# Altium schematic sheet RFD900x Adapter - 2.SchDoc("RFD900x Adapter - 2")
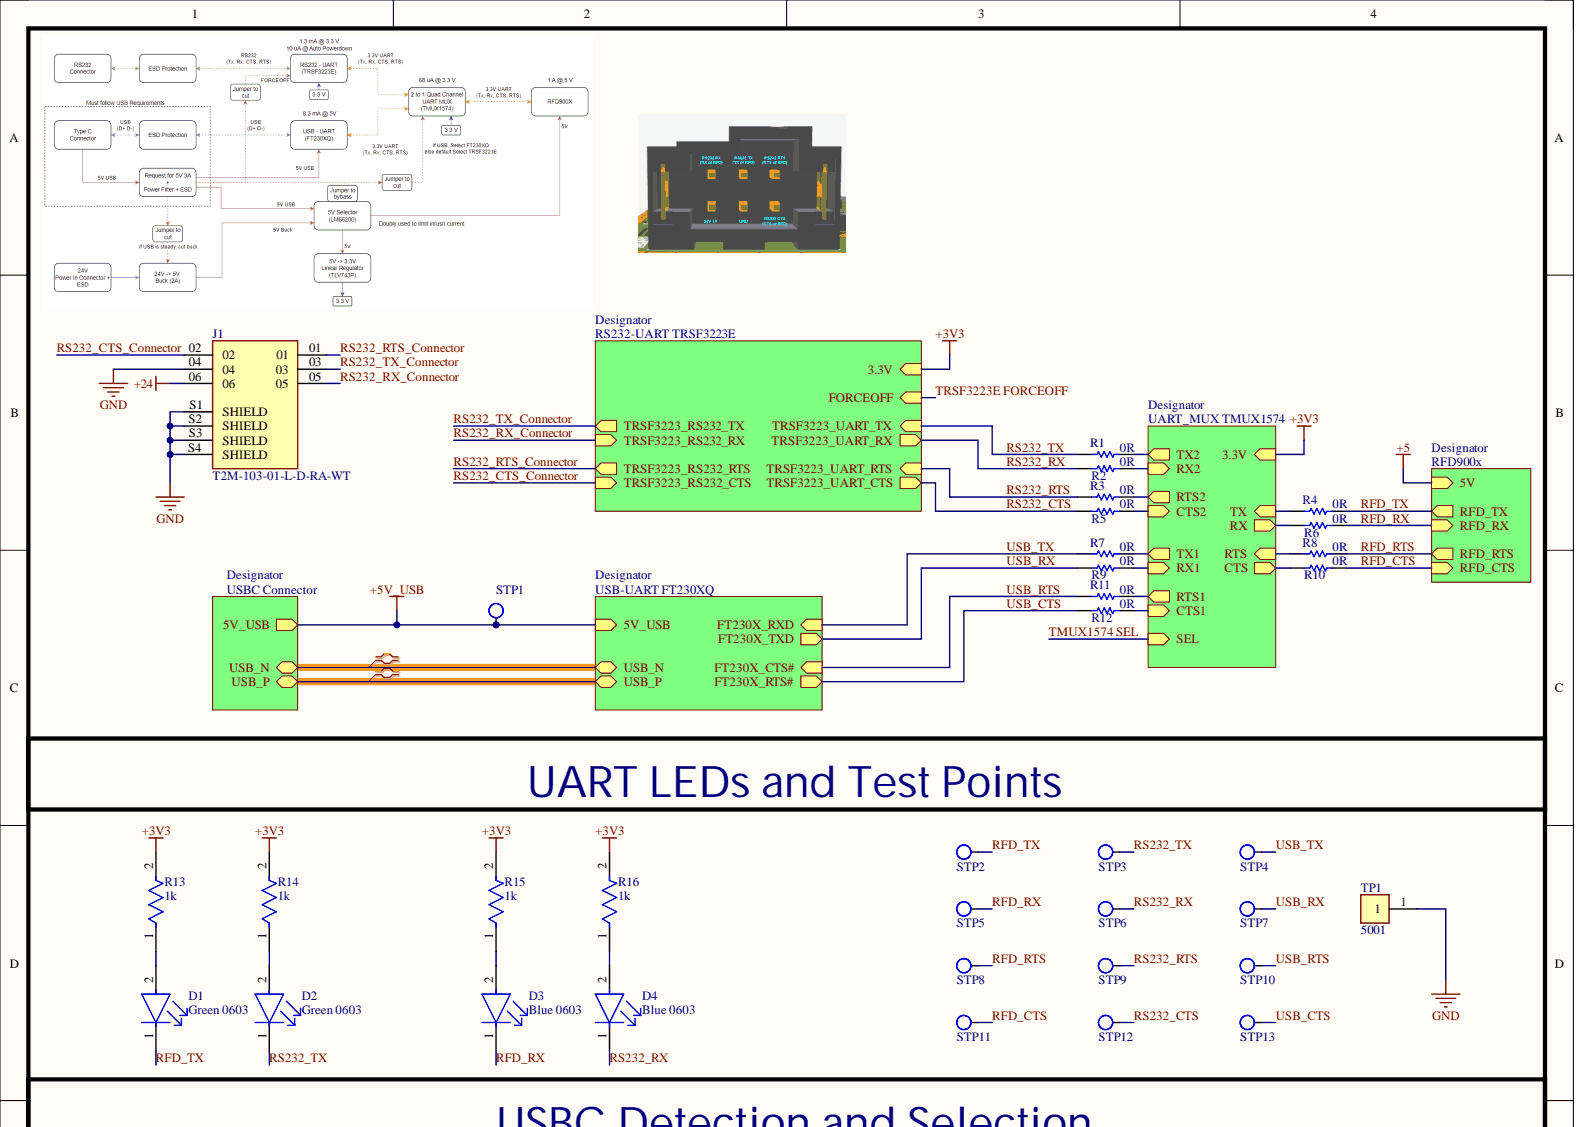
[diagram p0: RFD900x Adapter - 2.SchDoc, top]
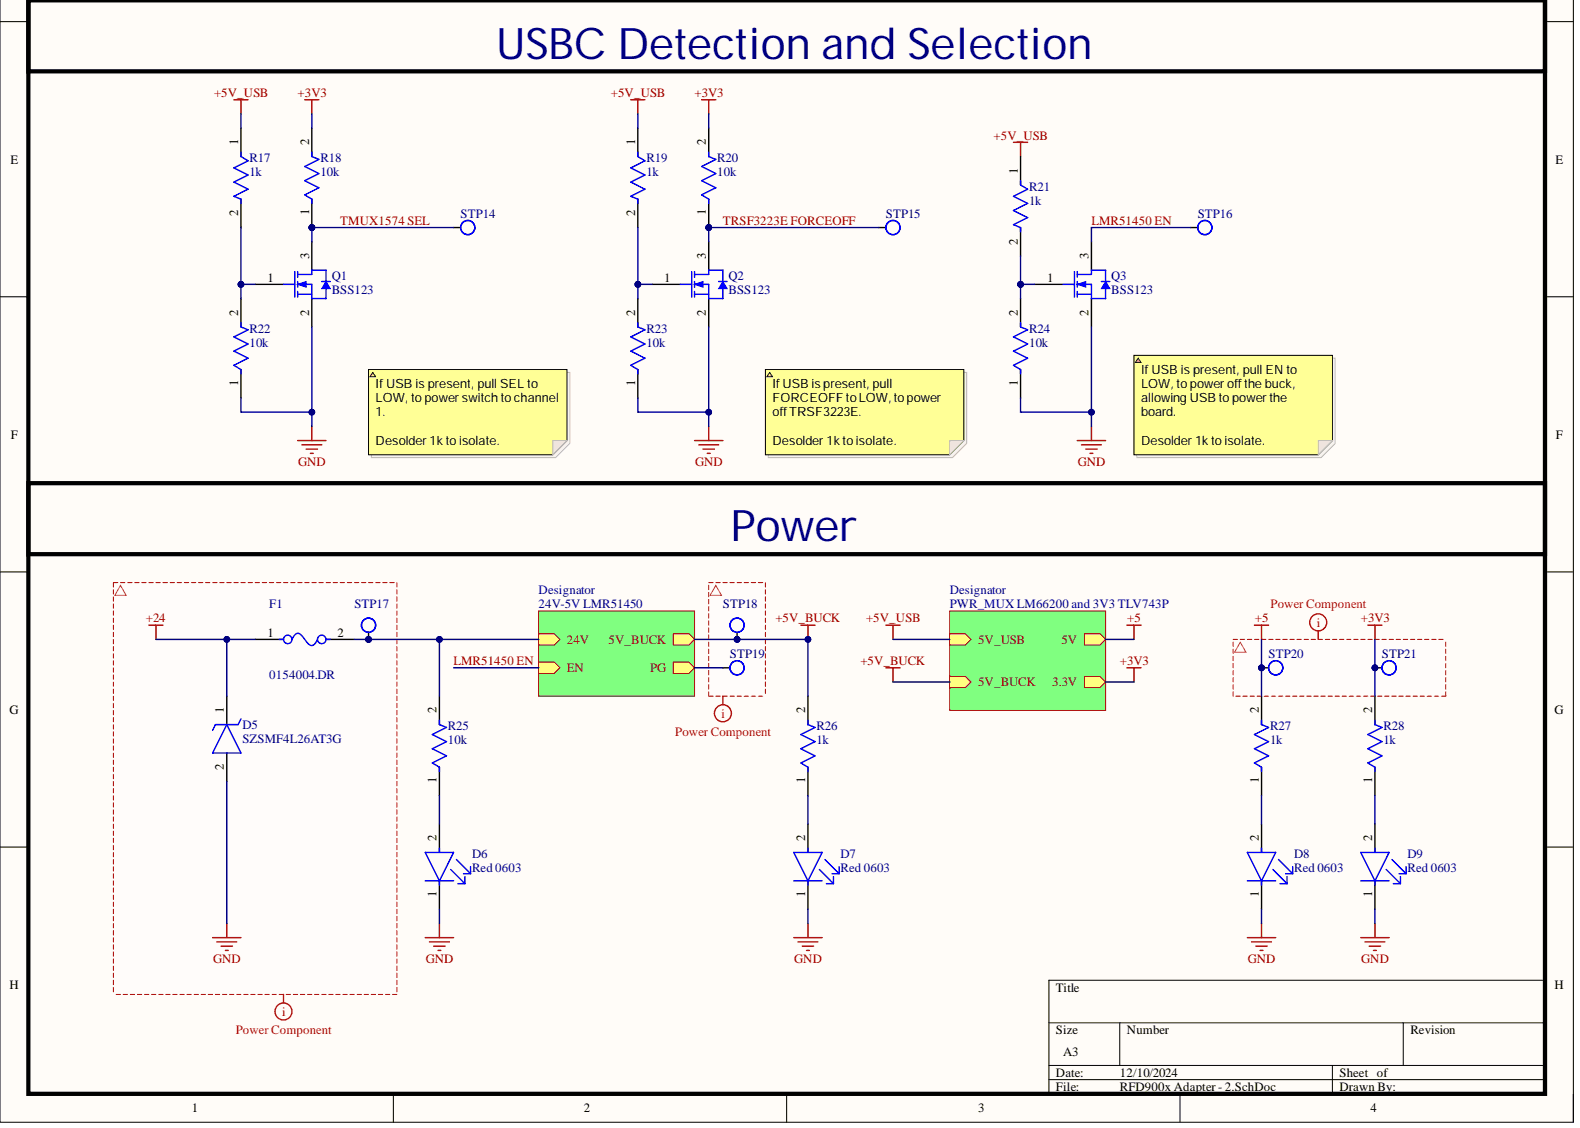
[diagram p1: RFD900x Adapter - 2.SchDoc, bottom]

## Parts
D1  Green 0603  Color: Green
D2  Green 0603  Case Code (Imperial): 0603  Color: Green
D3  Blue 0603  Color: Blue
D4  Blue 0603  Case Code (Imperial): 0603  Color: Blue
D5  SZSMF4L26AT3G
D6  Red 0603  Case Code (Imperial): 0603  Color: Red
D7  Red 0603  Case Code (Imperial): 0603  Color: Red
D8  Red 0603  Case Code (Imperial): 0603  Color: Red
D9  Red 0603  Case Code (Imperial): 0603  Color: Red
F1  0154004.DR
J1  T2M-103-01-L-D-RA-WT
Q1  BSS123
Q2  BSS123
Q3  BSS123
R1  0R
R2  0R
R3  0R
R4  0R
R5  0R
R6  0R
R7  0R
R8  0R
R9  0R
R10  0R
R11  0R
R12  0R
R13  1k
R14  1k
R15  1k
R16  1k
R17  1k
R18  10k
R19  1k
R20  10k
R21  1k
R22  10k
R23  10k
R24  10k
R25  10k
R26  1k
R27  1k
R28  1k
STP1  Surface Test Point  (value not drawn)
STP2  Surface Test Point  (value not drawn)
STP3  Surface Test Point  (value not drawn)
STP4  Surface Test Point  (value not drawn)
STP5  Surface Test Point  (value not drawn)
STP6  Surface Test Point  (value not drawn)
STP7  Surface Test Point  (value not drawn)
STP8  Surface Test Point  (value not drawn)
STP9  Surface Test Point  (value not drawn)
STP10  Surface Test Point  (value not drawn)
STP11  Surface Test Point  (value not drawn)
STP12  Surface Test Point  (value not drawn)
STP13  Surface Test Point  (value not drawn)
STP14  Surface Test Point  (value not drawn)
STP15  Surface Test Point  (value not drawn)
STP16  Surface Test Point  (value not drawn)
STP17  Surface Test Point  (value not drawn)
STP18  Surface Test Point  (value not drawn)
STP19  Surface Test Point  (value not drawn)
STP20  Surface Test Point  (value not drawn)
STP21  Surface Test Point  (value not drawn)
TP1  5001

## Nets
+3V3: R13.2 R14.2 R15.2 R16.2 R18.2 R20.2 R28.2 STP21.1
+5: R27.2 STP20.1
+5V_BUCK: R26.2 STP18.1
+5V_USB: R17.1 R19.1 R21.1 STP1.1
+24: D5.1 F1.1 J1.06
GND: D5.2 D6.1 D7.1 D8.1 D9.1 J1.04 J1.S1(SHIELD) J1.S2(SHIELD) J1.S3(SHIELD) J1.S4(SHIELD) Q1.2 Q2.2 Q3.2 R22.1 R23.1 R24.1 TP1.1
LMR51450 EN: Q3.3 STP16.1
RFD_CTS: R10.2 STP11.1
RFD_RTS: R8.2 STP8.1
RFD_RX: D3.1 R6.2 STP5.1
RFD_TX: D1.1 R4.2 STP2.1
RS232_CTS: R5.1 STP12.1
RS232_CTS_Connector: J1.02
RS232_RTS: R3.1 STP9.1
RS232_RTS_Connector: J1.01
RS232_RX: D4.1 R2.1 STP6.1
RS232_RX_Connector: J1.05
RS232_TX: D2.1 R1.1 STP3.1
RS232_TX_Connector: J1.03
TMUX1574 SEL: Q1.3 R18.1 STP14.1
TRSF3223E FORCEOFF: Q2.3 R20.1 STP15.1
USB_CTS: R12.1 STP13.1
USB_RTS: R11.1 STP10.1
USB_RX: R9.1 STP7.1
USB_TX: R7.1 STP4.1
NetD1_2: D1.2 R13.1
NetD2_2: D2.2 R14.1
NetD3_2: D3.2 R15.1
NetD4_2: D4.2 R16.1
NetD6_2: D6.2 R25.1
NetD7_2: D7.2 R26.1
NetD8_2: D8.2 R27.1
NetD9_2: D9.2 R28.1
NetF1_2: F1.2 R25.2 STP17.1
NetQ1_1: Q1.1 R17.2 R22.2
NetQ2_1: Q2.1 R19.2 R23.2
NetQ3_1: Q3.1 R21.2 R24.2
NetR1_2: R1.2
NetR2_2: R2.2
NetR3_2: R3.2
NetR4_1: R4.1
NetR5_2: R5.2
NetR6_1: R6.1
NetR7_2: R7.2
NetR8_1: R8.1
NetR9_2: R9.2
NetR10_1: R10.1
NetR11_2: R11.2
NetR12_2: R12.2
NetSTP19_1: STP19.1
USB_N:
USB_P:

## Library data of the parts
D1 D2 = CMP-00011-1: Led, Green, 570 Nm, 2 V, 30 Ma, 40 Mcd Rohs Compliant: Yes; Manufacturer Part No.: 150060VS75000; Dominant Wavelength: 570nm; Forward Current: 20mA; Forward Voltage: 2V; Lens Color: Colorless; Lens Size: 0.7mm; Lens Style: Rectangular,Flat; Lens Transparency: Clear; Luminous Intensity: 40mcd; Power Rating: 72mW; Reverse Voltage (DC): 5V; Viewing Angle: 140°; Case Code (Metric): 1608; Case/Package: 0603; Component Kind: Standard; Height: 0.8mm; Illumination Color: Green; Length: 1.6mm; Max Operating Temperature: 85°C; Min Operating Temperature: -40°C; Mounting Technology: Surface Mount; Package Quantity: 4000; Packaging: Cut Tape; Pins: 2; RoHS Compliant: Yes; Series: WL-SMCW; Test Current: 20mA; Weight: 0.000882oz; Width: 0.8mm; Library Name: BumbleBee Autonomous Systems - NUS
  D1: Case Code (Imperial): 0603
D3 D4 = CMP-00012-1: Led, Blue, 470 Nm, 3.2 V, 30 Ma, 145 Mcd Rohs Compliant: Yes; Manufacturer Part No.: 150060BS75000; Dominant Wavelength: 470nm; Forward Current: 30mA; Forward Voltage: 3.2V; Lens Color: Colorless; Lens Size: 0.7mm; Lens Style: Clear,Flat,Rectangular; Lens Transparency: Clear; Luminous Intensity: 145mcd; Test Current: 20mA; Viewing Angle: 140°; Case Code (Metric): 1608; Case/Package: 0603; Component Kind: Standard; Height: 0.8mm; Length: 1.6mm; Max Operating Temperature: 85°C; Min Operating Temperature: -40°C; Mounting Technology: Surface Mount; Package Quantity: 1; Packaging: Cut Tape; Reach SVHC Compliant: Unknown; RoHS Compliant: Yes; Series: WL-SMCW; Wavelength: 470nm; Width: 0.8mm; Library Name: BumbleBee Autonomous Systems - NUS
  D3: Case Code (Imperial): 0603
D5 = SZSMF4L26AT3G: TVS Diode (Uni-directional); Manufacturer_Name: LITTELFUSE; Component Kind: Standard; Datasheet Link: https://www.littelfuse.com/media?resourcetype=datasheets&itemid=4993539a-258a-401f-900a-f8327ceba4a0&filename=tvs-diode-szsmf4l-datasheet; Height: 1.4mm; Manufacturer_Part_Number: SZSMF4L26AT3G; Mouser Part Number: 576-SZSMF4L26AT3G; Mouser Price/Stock: https://www.mouser.co.uk/ProductDetail/Littelfuse/SZSMF4L26AT3G?qs=M%2FWrVUahkW%2Fiqh8fpg0UgQ%3D%3D
D6-D9 = CMP-00010-2: Led Red Diffused 0603 SMD; Manufacturer Part No.: 150060RS55040; Dominant Wavelength: 624nm; Forward Voltage: 2V; Lens Size: 0.8mm; Lens Style: Flat; Luminous Intensity: 90mcd; Test Current: 20mA; Viewing Angle: 140°; Case Code (Metric): 1608; Case/Package: 0603; Component Kind: Standard; Datasheet: https://www.farnell.com/datasheets/2621644.pdf?src-supplier=Farnell; Height: 0.5mm; Length: 1.6mm; Lens Transparency: Diffused; Mounting Technology: Surface Mount; Packaging: Cut Tape; RoHS Compliant: Yes; Series: WL-SMDC; Width: 0.8mm; Library Name: BumbleBee Autonomous Systems - NUS
F1 = CMP-08605-000003-1: FUSE BRD MNT 4A 125VAC/VDC 2SMD; Manufacturer: Littelfuse; Fuse Blow Speed: Fast; Max Fuse Ac Voltage: 125V; Automotive: No; Category: Fuse; Component Kind: Standard; ComponentLink2Description: Datasheet; ComponentLink2URL: https://www.littelfuse.com/~/media/electronics/datasheets/fuses/littelfuse_fuse_154_154t_154l_154tl_datasheet.pdf.pdf; Device Class L1: Circuit Protection; Device Class L2: Fuses; Device Class L3: unset; Digikey Description: FUSE BRD MNT 4A 125VAC/VDC 2SMD; Fuse Current: 4A; Height: 3.81mm; Lead Free: Yes; Library Name: Altium Content Vault; Manufacturer Part Number: 0154004.DR; Max Fuse Dc Voltage: 125V; Mouser Description: Surface Mount Fuses Fuseblock w/ fuse 4A OMNI BLOK 154; Octopart: https://octopart.com/0154004.dr-littelfuse-565861; Package: 0154; RoHS: TRUE; Temperature: -55°C to +125°C; Temperature Range High: +125°C; Temperature Range Low: -55°C
J1 = CMP-338-000000-2: MF: Samtec; Availability: Unavailable; Component Kind: Standard; Component Type: Standard; Design Item ID: T2M-103-01-L-D-RA-WT; MP: T2M-103-01-L-D-RA-WT; Package: None; Pin Count: 10; Price: None; Source: T2M-103-01-L-D-RA-WT.SchLib; Library Name: BumbleBee Autonomous Systems - NUS
Q1-Q3 = CMP-2000-04934-1: N-Channel Logic Level Enhancement Mode Field Effect Transistor, 1.7 V, -55 to 150 degC, 3-Pin SOT-23, RoHS, Tape and Reel; Manufacturer: Fairchild; Channel Type: N; Maximum Continuous Drain Current: 0.17A; Maximum Drain Source Voltage: 100V; Maximum Gate Source Voltage: ±20V; Package Version: Rev. 12, 03/2016; Rad Hard: No; RDS-on: 6000@10VmOhm; Typical Fall Time: 2.4ns; Typical Rise Time: 9ns; Typical Turn-Off Delay Time: 17ns; Typical Turn-On Delay Time: 1.7ns; Category: MOSFET; Channel Mode: Enhancement; Component Kind: Standard; Datasheet URL: https://www.fairchildsemi.com/datasheets/BS/BSS123.pdf; Datasheet Version: Jun-03; Library Name: Altium Content Vault; Manufacturer URL: https://www.fairchildsemi.com/; Mounting: SurfaceMount; Operating Temperature: -55to150degC; Package: 3SOT-23; Package Description: 3-Pin Small Outline Transistor, Body 2.92 x 1.325 mm, Pitch 0.95 mm; Package Reference: SOT23-3; Package URL: http://www.fairchildsemi.com/dwg/MA/MA03D.pdf; Packaging: Tape&Reel
R1-R12 = CMP-147-000043-1: CRCW04020000Z0EDC. Fixed Resistor, Metal Glaze/thick Film, 0.063W, 0ohm, Surface Mount, 0402; Component Kind: Standard; Library Name: BumbleBee Autonomous Systems - NUS
R13-R17 R19 R21 R26-R28 = CMP-1659-00009-5: RC0603FR-071KL. RES 1K OHM 1% 1/10W 0603; Max Power Dissipation: 100mW; Resistance: 1kR; Temperature Coefficient: 100ppm/°C; Voltage Rating: 50V; Case Code (Imperial): 0603; Case Code (Metric): 1608; Case/Package: 0603; Component Kind: Standard; Construction: Rectangular; Contact Plating: Tin; Depth: 0.8mm; Features: Moisture Resistant; Height: 0.45mm; Lead Free: Lead Free; Length: 1.6mm; Library Name: Altium Content Vault; Max Operating Temperature: 155°C; Military Standard: Not; Min Operating Temperature: -55°C; Number of Terminations: 2; Packaging: Tape and Reel; Power Rating: 100mW; Radiation Hardening: No; REACH SVHC: No SVHC; Resistance Tolerance: 1%; Resistor Type: Thick Film; RoHS Compliant: Yes; Series: RC; Size Code: 0603; Technology: THICK FILM; Termination: SMD/SMT; Tolerance: 1%
R18 R20 R22-R25 = CMP-05422-000107-1: ERJ-PB3B1002V. RES SMD 10K OHM 0.1% 1/5W 0603; Max Power Dissipation: 200mW; Resistance: 10kR; Temperature Coefficient: 50ppm/°C; Case Code (Imperial): 0603; Case Code (Metric): 1608; Case/Package: 0603; Component Kind: Standard; Features: Automotive AEC-Q200; Height - Seated (Max): 0.022inch; Length: 0.063inch; Library Name: Altium Content Vault; Max Operating Temperature: 155°C; Min Operating Temperature: -55°C; Number of Terminations: 2; Packaging: Tape and Reel; Resistor Type: Thick Film; Tolerance: 0.1%; Width: 0.032inch
STP1-STP21 = CMP-001-0000-2: Component Kind: Standard; Description: Surface Test Point; Library Name: BumbleBee Autonomous Systems - NUS
TP1 = CMP-2000-05181-2: PC TEST POINT MINIATURE BLACK; Body Material: Bronze; Hole Diameter: 1.6mm; Inside Diameter: 1.27mm; Insulation: Insulated; Number of Contacts: 1; Outside Diameter: 2.54mm; Plating: Silver; Color: Black; Component Kind: Standard; Contact Material: Bronze; Contact Plating: Silver; Diameter: 2.54mm; Flammability Rating: UL94 V-0; Height: 0.3inch; Housing Color: Black; Insulation Material: Nylon; Lead Free: Lead Free; Length: 7.62mm; Library Name: Altium Content Vault; Material: Nylon; Mount: Through Hole; Number of Ports: 1; Orientation: Straight; Package Quantity: 100; Packaging: Bulk; REACH SVHC: No SVHC; RoHS Compliant: Yes; Series: THM; Termination: Through Hole
